# Altium schematic sheet Messsystem.SchDoc
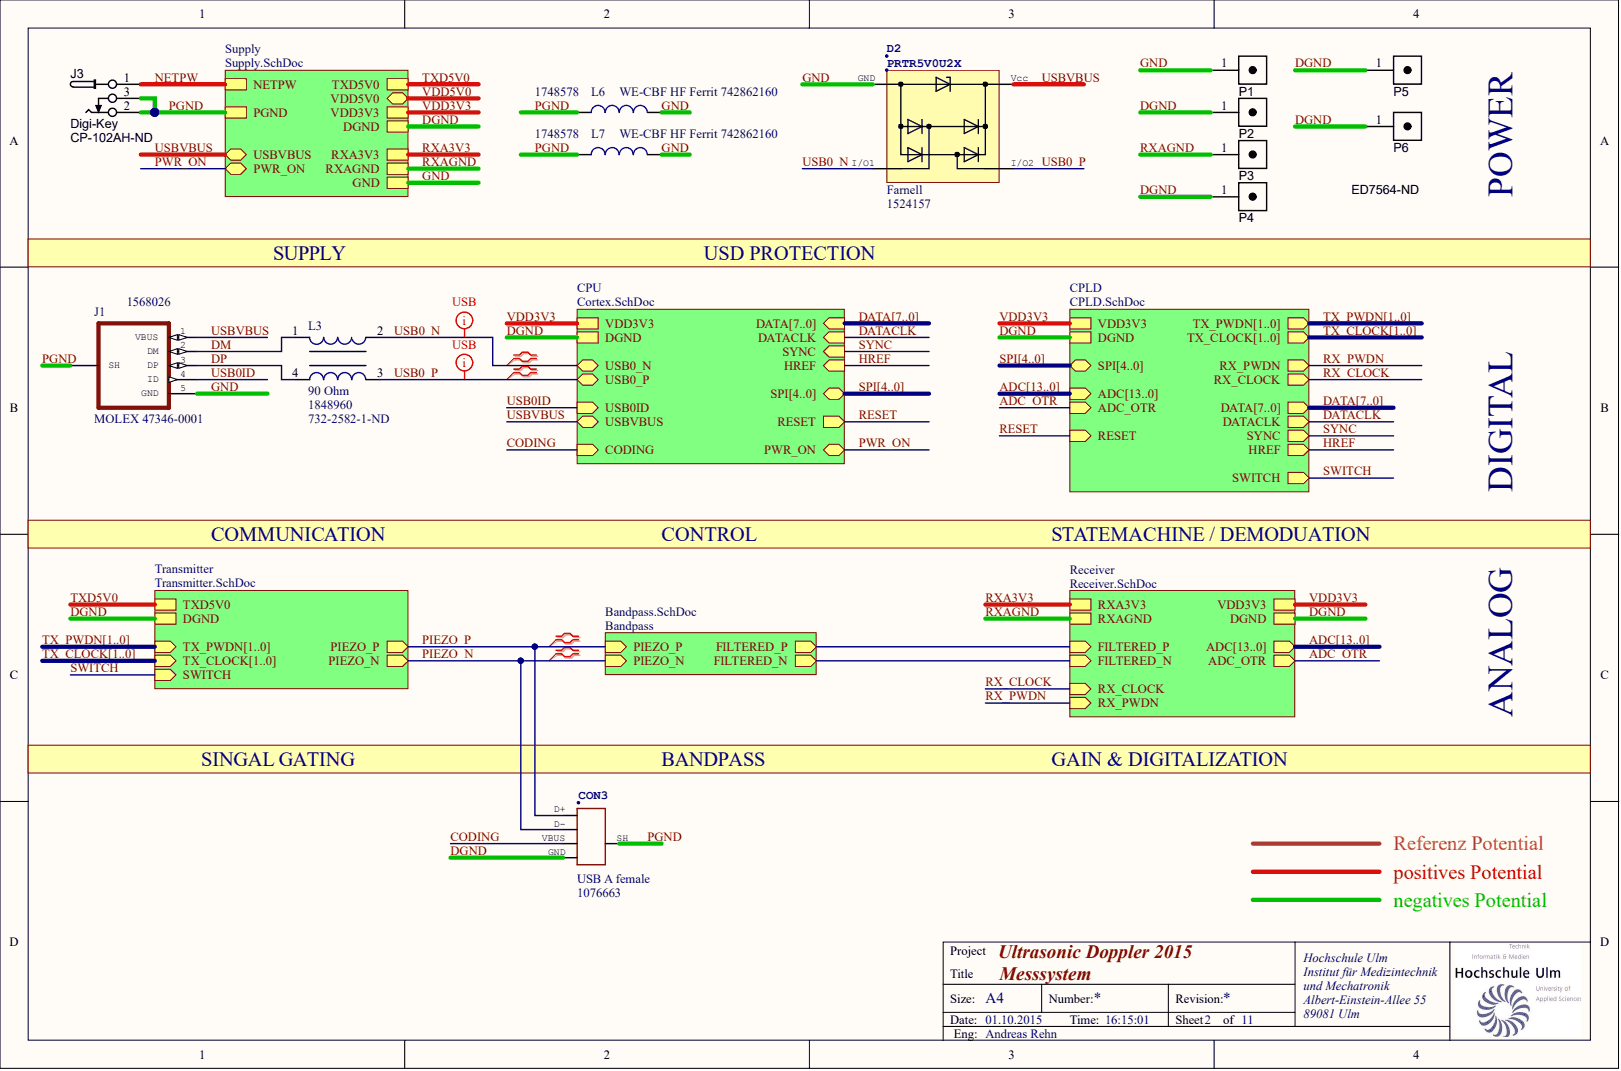
[diagram p0: Messsystem.SchDoc]

## Parts
CON3  USB A female  Supplier Part Number 1: 1076663  [USB A female]
D2  PRTR5V0U2X  Supplier 1: Farnell  Supplier Part Number 1: 1524157  [SOT143-B]
J1  MOLEX 47346-0001  Supplier Part Number 1: 1568026  [USB Micro]
J3  Supplier 1: Digi-Key  Supplier Part Number 1: CP-102AH-ND  [CONN_PWR_JACK_2.1x5.5mm]  (hidden comment: CONN_PWR_JACK_2.1x5.5mm)
L3  90 Ohm  Supplier Part Number 1: 1848960  [WE-CNSW, 0603]
L6  WE-CBF HF Ferrit 742862160  Supplier Part Number 1: 1748578  [0603[1508]]
L7  WE-CBF HF Ferrit 742862160  Supplier Part Number 1: 1748578  [0603[1508]]
P1  CONN_HEADER_PLUG_1x1P_0.100in  [1x1POS_HEADER_LOWPROF]  (value not drawn)
P2  CONN_HEADER_PLUG_1x1P_0.100in  [1x1POS_HEADER_LOWPROF]  (value not drawn)
P3  CONN_HEADER_PLUG_1x1P_0.100in  [1x1POS_HEADER_LOWPROF]  (value not drawn)
P4  CONN_HEADER_PLUG_1x1P_0.100in  [1x1POS_HEADER_LOWPROF]  (value not drawn)
P5  CONN_HEADER_PLUG_1x1P_0.100in  [1x1POS_HEADER_LOWPROF]  (value not drawn)
P6  CONN_HEADER_PLUG_1x1P_0.100in  [1x1POS_HEADER_LOWPROF]  (value not drawn)

## Nets
CODING: CON3.VBUS
DGND: CON3.GND P2.1 P4.1 P5.1 P6.1
DM: J1.2(DM) L3.1
DP: J1.3(DP) L3.4
GND: D2.GND J1.5(GND) L6.2 L7.2 P1.1
NETPW: J3.1
PGND: CON3.SH J1.SH J3.2 J3.3 L6.1 L7.1
PIEZO_N: CON3.D-
PIEZO_P: CON3.D+
RXAGND: P3.1
USB0_N: D2.I/O1 L3.2
USB0_P: D2.I/O2 L3.3
USB0ID: J1.4(ID)
USBVBUS: D2.Vcc J1.1(VBUS)
ADC0:  [also labelled ADC]
ADC1:  [also labelled ADC]
ADC2:  [also labelled ADC]
ADC3:  [also labelled ADC]
ADC4:  [also labelled ADC]
ADC5:  [also labelled ADC]
ADC6:  [also labelled ADC]
ADC7:  [also labelled ADC]
ADC8:  [also labelled ADC]
ADC9:  [also labelled ADC]
ADC10:  [also labelled ADC]
ADC11:  [also labelled ADC]
ADC12:  [also labelled ADC]
ADC13:  [also labelled ADC]
ADC_OTR:
DATA0:  [also labelled DATA]
DATA1:  [also labelled DATA]
DATA2:  [also labelled DATA]
DATA3:  [also labelled DATA]
DATA4:  [also labelled DATA]
DATA5:  [also labelled DATA]
DATA6:  [also labelled DATA]
DATA7:  [also labelled DATA]
DATACLK:
FILTERED_N:
FILTERED_P:
HREF:
N00104:
N00105:
N00106:
PWR_ON:
RESET:
RX_CLOCK:
RX_PWDN:
RXA3V3:
SPI0:  [also labelled SPI]
SPI1:  [also labelled SPI]
SPI2:  [also labelled SPI]
SPI3:  [also labelled SPI]
SPI4:  [also labelled SPI]
SWITCH:
SYNC:
TX_CLOCK0:  [also labelled TX_CLOCK]
TX_CLOCK1:  [also labelled TX_CLOCK]
TX_PWDN0:  [also labelled TX_PWDN]
TX_PWDN1:  [also labelled TX_PWDN]
TXD5V0:
VDD3V3:
VDD5V0:

## Library data of the parts
CON3 = USB-MB-S: Component Kind: Standard; Supplier 1: Farnell; Library Name: USD Parts.IntLib
D2 = PRTR5V0: rail-to-rail ESD protection diode; Component Kind: Standard; value: PRTR5V0U2X; Library Name: USD Parts.IntLib
J1 = USB: MICRO USB 2.0 BUCHSE, TYP B, SMD; Component Kind: Standard; ComponentLink1Description: DataSheet; ComponentLink1URL: http://www.farnell.com/datasheets/1681853.pdf; Supplier 1: Farnell; value: MOLEX 47346-0001; Library Name: USD Parts.IntLib
J3 = CONN_PWR_JACK_2.1x5.5mm: Power Connector, THT, 2.1mm ID, 5.5mm OD; Manufacturer 1: CUI Inc; Manufacturer Part Number 1: PJ-102AH; SCDType: undef; Category: Connectors; Component Kind: Standard; Mounting Type: Through Hole; Package / Case: PCB mount; Value: 2.1mm ID, 5.5mm OD; Library Name: USD Parts.IntLib
L3 = WE-CNSW 0603, 744230900: SMD Common Mode Noise Suppressor WE-CNSW,  Z= 90 Ohm; Manufacturer: Würth Elektronik eiSos GmbH; Component Kind: Standard; ComponentLink1Description: Manufacturer; ComponentLink1URL: http://www.we-online.de; ComponentLink2Description: Datasheet; ComponentLink2URL: http://www.we-online.de/katalog/media/pdf/744230900.pdf; Created Date: March 2012; Impedance: 90 Ohm; Library Name: WE-CNSW.IntLib; partNo: 744230900; Supplier 1: Farnell; Supplier 2: Digi-Key; Supplier Part Number 2: 732-2582-1-ND; Created by: Christin Zierenner
L6 L7 = Inductor: Ferrit; Code_IEC: 1005; Code_JEITA: 0402; Component Kind: Standard; LatestRevisionDate: 29-May-2009; LatestRevisionNote: IPC-7351 Footprint Added.; Library Name: Components.SchLib; PackageDescription: Chip Inductor; PackageReference: 0402-A; Published: 8-Jun-2000; Signal Integrity: Inductor; Simulation: INDUCTOR; Supplier 1: Farnell; Value: WE-CBF HF Ferrit 742862160; Publisher: Altium Limited
P1-P6 = CONN_HEADER_PLUG_1x1P_0.100in: Pin header for socket THT 1-Pos Low profile Single-row 2.54mm pitch; Manufacturer 1: Mill-Max Manufacturing Corp.; Manufacturer Part Number 1: 800-10-064-10-002000; Pitch: 0.100" (2.54mm); QtyOverride: 0.02; SCDType: undef; Value: 1x1 Pos.; Category: Connectors; Component Kind: Standard; Mounting Type: Through Hole; Package / Case: Socket header; 2.54mm pitch; Supplier 1: Digi-Key; Supplier Part Number 1: ED7564-ND; Library Name: USD Parts.IntLib
